# Altium schematic sheet heating_schematic_1.SchDoc (continued)
## Library data of the parts (continued)
C8 = GRM188R72A104KA35D (2): IRON_GATE_C0.1: 100V 100nF X7R ±10% 0603 Multilayer Ceramic Capacitors MLCC - SMD/SMT ROHS; Manufacturer: Murata; Manufacturer Lifecycle Status: IN PRODUCTION; Case Code (Imperial): 0603; Case Code (Metric): 1608; Case/Package: 0603; Category: Ceramic Capacitors; Component Kind: Standard; ComponentLink1Description: https://datasheet.octopart.com:443/GRM188R72A104KA35D-Murata-datasheet-44108070.pdf?src-supplier=Upverter; ComponentLink1URL: https://datasheet.octopart.com:443/GRM188R72A104KA35D-Murata-datasheet-44108070.pdf?src-supplier=Upverter; ComponentLink2Description: https://datasheet.octopart.com:443/GRM188R72A104KA35D-Murata-datasheet-141275792.pdf?src-supplier=Future+Electronics; ComponentLink2URL: https://datasheet.octopart.com:443/GRM188R72A104KA35D-Murata-datasheet-141275792.pdf?src-supplier=Future+Electronics; ComponentLink3Description: https://datasheet.octopart.com:443/GRM188R72A104KA35D-Murata-datasheet-32479577.pdf?src-supplier=Future+Electronics; ComponentLink3URL: https://datasheet.octopart.com:443/GRM188R72A104KA35D-Murata-datasheet-32479577.pdf?src-supplier=Future+Electronics; ComponentLink4Description: https://datasheet.octopart.com:443/GRM188R72A104KA35D-Murata-datasheet-14397338.pdf?src-supplier=Future+Electronics; ComponentLink4URL: https://datasheet.octopart.com:443/GRM188R72A104KA35D-Murata-datasheet-14397338.pdf?src-supplier=Future+Electronics; ComponentLink5Description: https://datasheet.octopart.com:443/GRM188R72A104KA35D-Murata-datasheet-62317223.pdf?src-supplier=element14+APAC; ComponentLink5URL: https://datasheet.octopart.com:443/GRM188R72A104KA35D-Murata-datasheet-62317223.pdf?src-supplier=element14+APAC; ComponentLink6Description: https://datasheet.octopart.com:443/GRM188R72A104KA35D-Murata-datasheet-17853129.pdf?src-supplier=element14+APAC; ComponentLink6URL: https://datasheet.octopart.com:443/GRM188R72A104KA35D-Murata-datasheet-17853129.pdf?src-supplier=element14+APAC; ComponentLink7Description: https://datasheet.octopart.com:443/GRM188R72A104KA35D-Murata-datasheet-8817987.pdf?src-supplier=Newark; ComponentLink7URL: https://datasheet.octopart.com:443/GRM188R72A104KA35D-Murata-datasheet-8817987.pdf?src-supplier=Newark; ComponentLink8Description: https://datasheet.octopart.com:443/GRM188R72A104KA35D-Murata-datasheet-5396244.pdf?src-supplier=_legacy+Avnet; ComponentLink8URL: https://datasheet.octopart.com:443/GRM188R72A104KA35D-Murata-datasheet-5396244.pdf?src-supplier=_legacy+Avnet; ComponentLink9Description: https://datasheet.octopart.com/GRM188R72A104KA35D-Murata-datasheet-12546599.pdf; ComponentLink9URL: https://datasheet.octopart.com/GRM188R72A104KA35D-Murata-datasheet-12546599.pdf; ComponentLink10Description: https://datasheet.octopart.com/GRM188R72A104KA35D-Murata-datasheet-12533551.pdf; ComponentLink10URL: https://datasheet.octopart.com/GRM188R72A104KA35D-Murata-datasheet-12533551.pdf; ComponentLink11Description: https://datasheet.octopart.com/GRM188R72A104KA35D-Murata-datasheet-12515295.pdf; ComponentLink11URL: https://datasheet.octopart.com/GRM188R72A104KA35D-Murata-datasheet-12515295.pdf; ComponentLink12Description: https://datasheet.octopart.com/GRM188R72A104KA35D-Murata-datasheet-12510076.pdf; ComponentLink12URL: https://datasheet.octopart.com/GRM188R72A104KA35D-Murata-datasheet-12510076.pdf; ComponentLink13Description: https://datasheet.octopart.com/GRM188R72A104KA35D-Murata-datasheet-11978611.pdf; ComponentLink13URL: https://datasheet.octopart.com/GRM188R72A104KA35D-Murata-datasheet-11978611.pdf; ComponentLink14Description: https://datasheet.octopart.com/GRM188R72A104KA35D-Murata-datasheet-10025737.pdf; ComponentLink14URL: https://datasheet.octopart.com/GRM188R72A104KA35D-Murata-datasheet-10025737.pdf; ComponentLink15Description: https://datasheet.octopart.com/GRM188R72A104KA35D-Murata-datasheet-8819587.pdf; ComponentLink15URL: https://datasheet.octopart.com/GRM188R72A104KA35D-Murata-datasheet-8819587.pdf; ComponentLink16Description: https://datasheet.octopart.com:443/GRM188R72A104KA35D-Murata-datasheet-165579666.pdf?src-supplier=TME; ComponentLink16URL: https://datasheet.octopart.com:443/GRM188R72A104KA35D-Murata-datasheet-165579666.pdf?src-supplier=TME; ComponentLink17Description: https://datasheet.octopart.com:443/GRM188R72A104KA35D-Murata-datasheet-59876780.pdf?src-supplier=TME; ComponentLink17URL: https://datasheet.octopart.com:443/GRM188R72A104KA35D-Murata-datasheet-59876780.pdf?src-supplier=TME; ComponentLink18Description: https://datasheet.octopart.com:443/GRM188R72A104KA35D-Murata-datasheet-140705814.pdf?src-supplier=Ciiva; ComponentLink18URL: https://datasheet.octopart.com:443/GRM188R72A104KA35D-Murata-datasheet-140705814.pdf?src-supplier=Ciiva; ComponentLink19Description: https://datasheet.octopart.com:443/GRM188R72A104KA35D-Murata-datasheet-138743342.pdf?src-supplier=Ciiva; ComponentLink19URL: https://datasheet.octopart.com:443/GRM188R72A104KA35D-Murata-datasheet-138743342.pdf?src-supplier=Ciiva; ComponentLink20Description: https://datasheet.octopart.com:443/GRM188R72A104KA35D-Murata-datasheet-120101189.pdf?src-supplier=Megastar; ComponentLink20URL: https://datasheet.octopart.com:443/GRM188R72A104KA35D-Murata-datasheet-120101189.pdf?src-supplier=Megastar; ComponentLink21Description: https://datasheet.octopart.com:443/GRM188R72A104KA35D-Murata-datasheet-7591359.pdf?src-supplier=Jameco; ComponentLink21URL: https://datasheet.octopart.com:443/GRM188R72A104KA35D-Murata-datasheet-7591359.pdf?src-supplier=Jameco; ComponentLink22Description: https://datasheet.octopart.com:443/GRM188R72A104KA35D-Murata-datasheet-118811.pdf?src-supplier=DigiKey; ComponentLink22URL: https://datasheet.octopart.com:443/GRM188R72A104KA35D-Murata-datasheet-118811.pdf?src-supplier=DigiKey; ComponentLink23Description: http://sigma.octopart.com:80/reach_statement/Murata-company-16.pdf?src-supplier=Compliance+Statements; ComponentLink23URL: http://sigma.octopart.com:80/reach_statement/Murata-company-16.pdf?src-supplier=Compliance+Statements; Country of Origin: Philippines; Depth: 800µm; Dielectric: X7R; Dielectric Material: Ceramic; Export Control Classification Number (ECCN) Code: EAR99; Harmonized Tariff Schedule (HTS) Code: 8532.24.00.20; Height: 800µm; Introduction Date: 2006-12-28; Lead Free: Lead Free; Length: 1.6mm; Library Name: Simulation Generic Components.IntLib; Lifecycle Status: Production; Manufacturer Part Number: GRM188R72A104KA35D; Material: Ceramic; Max Operating Temperature: 125°C; Min Operating Temperature: -55°C; Mounting Technology: Surface Mount; Number of Terminals: 2; Packaging: Tape and Reel; Pins: 2; Pricing: 1=0.14, 10=0.079, 50=0.0556, 100=0.0483, 500=0.03618, 1000=0.03244 (USD); Radiation Hardening: No; REACH SVHC: Yes; RoHS: Compliant; Schedule B: 8532240020, 8532240020|8532240020, 8532240020|8532240020|8532240020, 8532240020|8532240020|8532240020|8532240020; Stock: 1621610; Supplier: DigiKey; Supplier Part Number: 490-3285-1-ND; Termination: SMD/SMT; Thickness: 800µm; Tolerance: 10%; Voltage: 100V; Voltage Rating: 100V; Voltage Rating (DC): 100V; Weight: 6.293594mg; Width: 800µm
C9 = CL10B105KA8NNNC: IRON_GATE_C1: 25V 1uF X7R ±10% 0603 Multilayer Ceramic Capacitors MLCC - SMD/SMT ROHS; Manufacturer: Samsung Electro-Mechanics; Case Code (Imperial): 0603; Case Code (Metric): 1608; Case/Package: 0603; Category: Ceramic Capacitors; China RoHS: Compliant; Component Kind: Standard; ComponentLink1Description: https://datasheet.octopart.com:443/CL10B105KA8NNNC-Samsung-Electro-Mechanics-datasheet-182019882.pdf?src-supplier=IHS; ComponentLink1URL: https://datasheet.octopart.com:443/CL10B105KA8NNNC-Samsung-Electro-Mechanics-datasheet-182019882.pdf?src-supplier=IHS; ComponentLink2Description: https://datasheet.octopart.com:443/CL10B105KA8NNNC-Samsung-Electro-Mechanics-datasheet-106547815.pdf?src-supplier=Upverter; ComponentLink2URL: https://datasheet.octopart.com:443/CL10B105KA8NNNC-Samsung-Electro-Mechanics-datasheet-106547815.pdf?src-supplier=Upverter; ComponentLink3Description: https://datasheet.octopart.com:443/CL10B105KA8NNNC-Samsung-Electro-Mechanics-datasheet-137699931.pdf?src-supplier=Samsung+SCT; ComponentLink3URL: https://datasheet.octopart.com:443/CL10B105KA8NNNC-Samsung-Electro-Mechanics-datasheet-137699931.pdf?src-supplier=Samsung+SCT; ComponentLink4Description: https://datasheet.octopart.com:443/CL10B105KA8NNNC-Samsung-Electro-Mechanics-datasheet-116890983.pdf?src-supplier=Future+Electronics; ComponentLink4URL: https://datasheet.octopart.com:443/CL10B105KA8NNNC-Samsung-Electro-Mechanics-datasheet-116890983.pdf?src-supplier=Future+Electronics; ComponentLink5Description: https://datasheet.octopart.com:443/CL10B105KA8NNNC-Samsung-datasheet-12527851.pdf?src-supplier=Future+Electronics; ComponentLink5URL: https://datasheet.octopart.com:443/CL10B105KA8NNNC-Samsung-datasheet-12527851.pdf?src-supplier=Future+Electronics; ComponentLink6Description: https://datasheet.octopart.com:443/CL10B105KA8NNNC-Samsung-datasheet-26669022.pdf?src-supplier=Future+Electronics; ComponentLink6URL: https://datasheet.octopart.com:443/CL10B105KA8NNNC-Samsung-datasheet-26669022.pdf?src-supplier=Future+Electronics; ComponentLink7Description: https://datasheet.octopart.com:443/CL10B105KA8NNNC-Samsung-Electro-Mechanics-datasheet-149136795.pdf?src-supplier=Future+Electronics; ComponentLink7URL: https://datasheet.octopart.com:443/CL10B105KA8NNNC-Samsung-Electro-Mechanics-datasheet-149136795.pdf?src-supplier=Future+Electronics; ComponentLink8Description: https://datasheet.octopart.com:443/CL10B105KA8NNNC-Samsung-datasheet-37081328.pdf?src-supplier=Future+Electronics; ComponentLink8URL: https://datasheet.octopart.com:443/CL10B105KA8NNNC-Samsung-datasheet-37081328.pdf?src-supplier=Future+Electronics; ComponentLink9Description: https://datasheet.octopart.com/CL10B105KA8NNNC-Samsung-Electro-Mechanics-datasheet-97040617.pdf; ComponentLink9URL: https://datasheet.octopart.com/CL10B105KA8NNNC-Samsung-Electro-Mechanics-datasheet-97040617.pdf; ComponentLink10Description: https://datasheet.octopart.com/CL10B105KA8NNNC-Samsung-Electro-Mechanics-datasheet-8324245.pdf; ComponentLink10URL: https://datasheet.octopart.com/CL10B105KA8NNNC-Samsung-Electro-Mechanics-datasheet-8324245.pdf; ComponentLink11Description: https://datasheet.octopart.com:443/CL10B105KA8NNNC-Samsung-datasheet-59220082.pdf?src-supplier=TME; ComponentLink11URL: https://datasheet.octopart.com:443/CL10B105KA8NNNC-Samsung-datasheet-59220082.pdf?src-supplier=TME; ComponentLink12Description: https://datasheet.octopart.com:443/CL10B105KA8NNNC-Samsung-Electro-Mechanics-datasheet-11897796.pdf?src-supplier=Arrow+Electronics; ComponentLink12URL: https://datasheet.octopart.com:443/CL10B105KA8NNNC-Samsung-Electro-Mechanics-datasheet-11897796.pdf?src-supplier=Arrow+Electronics; Contact Plating: Tin; Country of Origin: Mainland China, Philippines, South Korea; Depth: 800µm; Dielectric: X7R; Dielectric Material: Ceramic; Export Control Classification Number (ECCN) Code: EAR99; Harmonized Tariff Schedule (HTS) Code: 8532.24.00.20; Height: 900µm; Introduction Date: 2009-11-02; Length: 1.6mm; Library Name: Simulation Generic Components.IntLib; Lifecycle Status: Production; Manufacturer Part Number: CL10B105KA8NNNC; Material: Ceramic; Max Operating Temperature: 125°C; Min Operating Temperature: -55°C; Mounting Technology: Surface Mount; Number of Terminals: 2; Pricing: 1=0.1, 10=0.036, 50=0.0244, 100=0.0208, 500=0.01486, 1000=0.01305 (USD); Radiation Hardening: No; REACH SVHC: Yes; RoHS: Compliant; Schedule B: 8532240020, 8532240020|8532240020, 8532240020|8532240020|8532240020, 8532240020|8532240020|8532240020|8532240020; Stock: 996256; Supplier: DigiKey; Supplier Part Number: 1276-1184-1-ND; Thickness: 889µm; Tolerance: 10%; Voltage: 25V; Voltage Rating: 25V; Voltage Rating (DC): 25V; Width: 800µm
D1 = SMBJ33A: TVS_DIODE: SMBJ Series 53.3 V 0.6 kW Surface Mount Uni-Directional TVS Diode - DO-214AA; Manufacturer: Littelfuse; Breakdown Voltage: 38.65V; Clamping Voltage: 53.3V; Direction: Unidirectional; Element Configuration: Single; Forward Voltage: 3.5V; Leakage Current: 1µA; Max Breakdown Voltage: 40.6V; Max Junction Temperature (Tj): 150°C; Max Power Dissipation: 5W; Max Surge Current: 11.3A; Min Breakdown Voltage: 36.7V; Number of Channels: 1; Operating Supply Voltage: 33V; Peak Pulse Power: 600W; Power Line Protection: No; Test Current: 1mA; Case/Package: SMB; Category: TVS Diodes; China RoHS: Non-Compliant; Component Kind: Standard; ComponentLink1Description: https://datasheet.octopart.com:443/SMBJ33A-Littelfuse-datasheet-180609570.pdf?src-supplier=IHS; ComponentLink1URL: https://datasheet.octopart.com:443/SMBJ33A-Littelfuse-datasheet-180609570.pdf?src-supplier=IHS; ComponentLink2Description: https://datasheet.octopart.com:443/SMBJ33A-Littelfuse-datasheet-98958142.pdf?src-supplier=Littelfuse+SCT; ComponentLink2URL: https://datasheet.octopart.com:443/SMBJ33A-Littelfuse-datasheet-98958142.pdf?src-supplier=Littelfuse+SCT; ComponentLink3Description: https://datasheet.octopart.com:443/SMBJ33A-Littelfuse-datasheet-10854588.pdf?src-supplier=element14+APAC; ComponentLink3URL: https://datasheet.octopart.com:443/SMBJ33A-Littelfuse-datasheet-10854588.pdf?src-supplier=element14+APAC; ComponentLink4Description: https://datasheet.octopart.com:443/SMBJ33A-Littelfuse-datasheet-5319440.pdf?src-supplier=element14+APAC; ComponentLink4URL: https://datasheet.octopart.com:443/SMBJ33A-Littelfuse-datasheet-5319440.pdf?src-supplier=element14+APAC; ComponentLink5Description: https://datasheet.octopart.com:443/SMBJ33A-Littelfuse-datasheet-145017494.pdf?src-supplier=Farnell; ComponentLink5URL: https://datasheet.octopart.com:443/SMBJ33A-Littelfuse-datasheet-145017494.pdf?src-supplier=Farnell; ComponentLink6Description: https://datasheet.octopart.com:443/SMBJ33A-Littelfuse-datasheet-63710821.pdf?src-supplier=Farnell; ComponentLink6URL: https://datasheet.octopart.com:443/SMBJ33A-Littelfuse-datasheet-63710821.pdf?src-supplier=Farnell; ComponentLink7Description: https://datasheet.octopart.com:443/SMBJ33A-Littelfuse-datasheet-170904961.pdf?src-supplier=Future+Electronics; ComponentLink7URL: https://datasheet.octopart.com:443/SMBJ33A-Littelfuse-datasheet-170904961.pdf?src-supplier=Future+Electronics; ComponentLink8Description: https://datasheet.octopart.com:443/SMBJ33A-Littelfuse-datasheet-27889791.pdf?src-supplier=Fuses+Unlimited; ComponentLink8URL: https://datasheet.octopart.com:443/SMBJ33A-Littelfuse-datasheet-27889791.pdf?src-supplier=Fuses+Unlimited; ComponentLink9Description: https://datasheet.octopart.com:443/SMBJ33A-Littelfuse-datasheet-871.pdf?src-supplier=DigiKey; ComponentLink9URL: https://datasheet.octopart.com:443/SMBJ33A-Littelfuse-datasheet-871.pdf?src-supplier=DigiKey; Composition: Zener; Country of Origin: Mainland China, Philippines; Export Control Classification Number (ECCN) Code: EAR99; Harmonized Tariff Schedule (HTS) Code: 8541.10.00.50; Height: 2.61mm; Introduction Date: 1996-01-01; Lead Free: Lead Free; Length: 4.57mm; Lifecycle Status: Production; Manufacturer Part Number: SMBJ33A; Max Operating Temperature: 150°C; Max Reverse Leakage Current: 1µA; Min Operating Temperature: -65°C; Mounting Technology: Surface Mount; Number of Elements: 1; Number of Terminals: 2; Number of Unidirectional Channels: 1; Packaging: Tape & Reel; Peak Pulse Current: 11.3A; Peak Reverse Current: 1µA; Pins: 2; Polarity: Unidirectional; Power Rating: 600W; Pricing: 1=0.3, 10=0.214, 100=0.105, 500=0.102, 1000=0.098 (USD); Radiation Hardening: No; REACH SVHC: No; Reverse Breakdown Voltage: 36.7V; Reverse Standoff Voltage: 33V; Reverse Voltage: 33V; RoHS: Compliant; Schedule B: 8541100080, 8541100080|8541100080|8541100080|8541100080|8541100080|8541100080|8541100080|8541100080|8541100080|8541100080|8541100080|8541100080|8541100080|8541100080|8541100080|8541100080|8541100080|8541100080|8541100080|8541100080|8541100080|8541100080|8541100080|85; Stock: 63760; Supplier: DigiKey; Supplier Part Number: SMBJ33ALFCT-ND; Termination: SMD/SMT; Voltage Rating (DC): 33V; Width: 3.94mm; Library Name: Americas - University of Waterloo - Waterloo Space Soldering Team (WSST)
F1 = 0680L9100-05: INPUT_FUSE: Electric Fuse, Time Lag Blow, 10A, 75VAC, 50VDC, 100A (IR), Surface Mount, 2410; Manufacturer: Bel Fuse; Time to Trip: 10ms; Voltage Rating (AC): 75V; Voltage Rating (DC): 50V; Category: Fuses; China RoHS: Non-Compliant; Component Kind: Standard; ComponentLink1Description: https://datasheet.octopart.com:443/0680L9100-05-Bel-Fuse-datasheet-150518765.pdf?src-supplier=Master+Electronics; ComponentLink1URL: https://datasheet.octopart.com:443/0680L9100-05-Bel-Fuse-datasheet-150518765.pdf?src-supplier=Master+Electronics; ComponentLink2Description: https://datasheet.octopart.com:443/0680L9100-05-Bel-Fuse-datasheet-139885425.pdf?src-supplier=Sager+Electronics; ComponentLink2URL: https://datasheet.octopart.com:443/0680L9100-05-Bel-Fuse-datasheet-139885425.pdf?src-supplier=Sager+Electronics; ComponentLink3Description: https://datasheet.octopart.com:443/0680L9100-05-Bel-Fuse-datasheet-137316783.pdf?src-supplier=Sager+Electronics; ComponentLink3URL: https://datasheet.octopart.com:443/0680L9100-05-Bel-Fuse-datasheet-137316783.pdf?src-supplier=Sager+Electronics; Country of Origin: Mainland China; Current Rating: 10A; Export Control Classification Number (ECCN) Code: EAR99; Harmonized Tariff Schedule (HTS) Code: 8536.10.00.40; Height: 2.54mm; Introduction Date: 2019-07-26; Length: 6.1mm; Lifecycle Status: Production; Manufacturer Part Number: 0680L9100-05; Max Operating Temperature: 125°C; Min Operating Temperature: -55°C; Mounting Technology: Surface Mount; Pricing: 1=0.74, 5=0.61, 10=0.563, 50=0.467, 100=0.4309, 250=0.38732, 500=0.35722, 1000=0.32936 (USD); REACH SVHC: No; RoHS: Compliant; Stock: 10120; Supplier: DigiKey; Supplier Part Number: 5923-0680L9100-05CT-ND; Library Name: Americas - University of Waterloo - Waterloo Space Soldering Team (WSST)
J1 = OSTTC022162: Terminal Block Header 2-Position Top Screw 0.200" (5.08mm) PC-Pins; Manufacturer: On Shore Technology; Approvals: UL, cUL; Current: 15A; Number of Contacts: 2; Number of Levels: 1; Plating: Steel; Voltage: 300V; Wire Gauge (Max): 22AWG; Wire Gauge (Min): 14AWG; Category: Terminal Blocks; Color: Blue; Component Kind: Standard; ComponentLink1Description: https://datasheet.octopart.com:443/OSTTC022162-On-Shore-Technology-datasheet-154400322.pdf?src-supplier=IHS; ComponentLink1URL: https://datasheet.octopart.com:443/OSTTC022162-On-Shore-Technology-datasheet-154400322.pdf?src-supplier=IHS; ComponentLink2Description: https://datasheet.octopart.com:443/OSTTC022162-On-Shore-Technology-datasheet-138504.pdf?src-supplier=Jameco; ComponentLink2URL: https://datasheet.octopart.com:443/OSTTC022162-On-Shore-Technology-datasheet-138504.pdf?src-supplier=Jameco; Contact Material: Brass; Contact Plating: Tin; Country of Origin: Mainland China, Tunisia; Current Rating: 15A; Export Control Classification Number (ECCN) Code: EAR99; Flammability Rating: UL94 V-0; Harmonized Tariff Schedule (HTS) Code: 8536.90.40.00; Height: 10mm; Housing Color: Blue; Housing Material: Thermoplastic; Introduction Date: 2007-04-24; Length: 10.2mm; Lifecycle Status: Production; Manufacturer Part Number: OSTTC022162; Max Current Rating: 15A; Max Operating Temperature: 105°C; Min Operating Temperature: -30°C; Mounting Technology: Screw; Number of Positions: 2; Number of Positions Per Level: 2; Number of Rows: 1; Packaging: Bulk; Pitch: 5.08mm; Pricing: 1=0.57, 10=0.423, 25=0.3692, 50=0.3322, 100=0.3, 250=0.26432, 500=0.24256, 1000=0.22482, 5000=0.19622 (USD); REACH SVHC: Yes; RoHS: Compliant; Stock: 7662; Supplier: DigiKey; Supplier Part Number: ED2609-ND; Termination: Screw; Voltage Rating: 300V; Width: 7.6mm; Wire/Cable Gauge: 14AWG; Library Name: heating_sch_lib1.SchLib
J2 = OSTYK51102030: Conn Terminal Block 2 POS 9.5mm Solder ST Thru-Hole 30A; Manufacturer: On Shore Technology; Approvals: UL, cUL; Number of Circuits: 2; Number of Levels: 1; Pitch: 9.4996mm; Voltage Rating: 300V; Wire Gauge (Max): 22AWG; Wire Gauge (Min): 10AWG; Category: Terminal Blocks; Color: Black; Component Kind: Standard; ComponentLink1Description: https://datasheet.octopart.com:443/OSTYK51102030-On-Shore-Technology-datasheet-180957037.pdf?src-supplier=IHS; ComponentLink1URL: https://datasheet.octopart.com:443/OSTYK51102030-On-Shore-Technology-datasheet-180957037.pdf?src-supplier=IHS; Country of Origin: Mainland China, Tunisia; Current Rating: 30A; Export Control Classification Number (ECCN) Code: EAR99; Flammability Rating: UL94 V-0; Harmonized Tariff Schedule (HTS) Code: 8536.90.40.00; Insulation Material: Nylon; Introduction Date: 2015-07-28; Lifecycle Status: Production; Manufacturer Part Number: OSTYK51102030; Max Operating Temperature: 115°C; Min Operating Temperature: -40°C; Mounting Technology: Screw; Number of Contacts: 2; Number of Rows: 1; Number of Wire Entries: 2; Packaging: Bulk; Pricing: 1=1.22, 10=0.886, 25=0.7716, 50=0.6962, 100=0.6316, 250=0.56228, 500=0.52082, 1000=0.48752, 5000=0.43471 (USD); REACH SVHC: Yes; RoHS: Compliant; Stock: 4503; Supplier: DigiKey; Supplier Part Number: ED2953-ND; Wire/Cable Gauge: 10AWG; Library Name: heating_sch_lib1.SchLib
J3 J4 = OSTTE020104: Conn Terminal Block 2 POS 3.5mm Solder ST Thru-Hole 10A; Manufacturer: On Shore Technology; Approvals: UL, cUL; Number of Contacts: 2; Number of Levels: 1; Pitch: 3.5052mm; Plating: Steel; Voltage: 125V; Wire Gauge (Max): 26AWG; Wire Gauge (Min): 16AWG; Category: Terminal Blocks; Color: Black; Component Kind: Standard; ComponentLink1Description: https://datasheet.octopart.com:443/OSTTE020104-On-Shore-Technology-datasheet-159568345.pdf?src-supplier=IHS; ComponentLink1URL: https://datasheet.octopart.com:443/OSTTE020104-On-Shore-Technology-datasheet-159568345.pdf?src-supplier=IHS; ComponentLink2Description: https://datasheet.octopart.com:443/OSTTE020104-On-Shore-Technology-datasheet-17019721.pdf?src-supplier=Upverter; ComponentLink2URL: https://datasheet.octopart.com:443/OSTTE020104-On-Shore-Technology-datasheet-17019721.pdf?src-supplier=Upverter; Contact Material: Tin; Contact Plating: Tin; Country of Origin: Mainland China, Tunisia; Current: 10A; Current Rating: 10A; Export Control Classification Number (ECCN) Code: EAR99; Harmonized Tariff Schedule (HTS) Code: 8536.90.40.00; Height: 8.7mm; Housing Material: Thermoplastic; Introduction Date: 2013-10-15; Lifecycle Status: Production; Manufacturer Part Number: OSTTE020104; Max Operating Temperature: 105°C; Min Operating Temperature: -40°C; Mounting Technology: Screw; Number of Positions Per Level: 2; Number of Rows: 1; Packaging: Bulk; Pins: 2; Pricing: 1=0.48, 10=0.361, 25=0.3152, 50=0.2836, 100=0.2558, 250=0.225, 500=0.2061, 1000=0.19063, 5000=0.16559 (USD); REACH SVHC: Yes; RoHS: Compliant; Stock: 15539; Supplier: DigiKey; Supplier Part Number: ED2740-ND; Termination: Screw; Voltage Rating: 125V; Wire/Cable Gauge: 16AWG; Library Name: heating_sch_lib1.SchLib
Q1 Q2 = IRLZ44NSTRLPBF: IRON_MOSFET: Mosfet, Power; N-ch; Vdss 55V; Rds(on) 0.022 Ohm; Id 47A; D2PAK; Pd 110W; Vgs +/-16V; -55; Manufacturer: Infineon; Drain to Source Resistance: 25mΩ; Element Configuration: Single; Fall Time: 15ns; Gate to Source Voltage (Vgs): 16V; Input Capacitance: 1.7nF; Max Junction Temperature (Tj): 175°C; Max Power Dissipation: 3.8W; Number of Channels: 1; On-State Resistance: 22mΩ; Rise Time: 84ns; Threshold Voltage: 2V; Turn-Off Delay Time: 26ns; Turn-On Delay Time: 11ns; Value: 22mΩ; Case/Package: D2PAK; Category: MOSFETs; China RoHS: Non-Compliant; Component Kind: Standard; ComponentLink1Description: https://datasheet.octopart.com:443/IRLZ44NSTRLPBF-Infineon-datasheet-7566683.pdf?src-supplier=Newark; ComponentLink1URL: https://datasheet.octopart.com:443/IRLZ44NSTRLPBF-Infineon-datasheet-7566683.pdf?src-supplier=Newark; ComponentLink2Description: https://datasheet.octopart.com:443/IRLZ44NSTRLPBF-International-Rectifier-datasheet-9326.pdf?src-supplier=Newark; ComponentLink2URL: https://datasheet.octopart.com:443/IRLZ44NSTRLPBF-International-Rectifier-datasheet-9326.pdf?src-supplier=Newark; ComponentLink3Description: https://datasheet.octopart.com:443/IRLZ44NSTRLPBF-Infineon-datasheet-180073547.pdf?src-supplier=IHS; ComponentLink3URL: https://datasheet.octopart.com:443/IRLZ44NSTRLPBF-Infineon-datasheet-180073547.pdf?src-supplier=IHS; ComponentLink4Description: https://datasheet.octopart.com:443/IRLZ44NSTRLPBF-Infineon-datasheet-8534184.pdf?src-supplier=Farnell; ComponentLink4URL: https://datasheet.octopart.com:443/IRLZ44NSTRLPBF-Infineon-datasheet-8534184.pdf?src-supplier=Farnell; ComponentLink5Description: https://datasheet.octopart.com:443/IRLZ44NSTRLPBF-Infineon-datasheet-9669855.pdf?src-supplier=RS+(Formerly+Allied+Electronics); ComponentLink5URL: https://datasheet.octopart.com:443/IRLZ44NSTRLPBF-Infineon-datasheet-9669855.pdf?src-supplier=RS+(Formerly+Allied+Electronics); ComponentLink6Description: https://datasheet.octopart.com:443/IRLZ44NSTRLPBF-International-Rectifier-datasheet-8827662.pdf?src-supplier=Jameco; ComponentLink6URL: https://datasheet.octopart.com:443/IRLZ44NSTRLPBF-International-Rectifier-datasheet-8827662.pdf?src-supplier=Jameco; ComponentLink7Description: https://datasheet.octopart.com:443/IRLZ44NSTRLPBF-International-Rectifier-datasheet-49149.pdf?src-supplier=DigiKey; ComponentLink7URL: https://datasheet.octopart.com:443/IRLZ44NSTRLPBF-International-Rectifier-datasheet-49149.pdf?src-supplier=DigiKey; ComponentLink8Description: http://sigma.octopart.com:80/conflict_mineral_statement/Infineon-company-51.pdf?src-supplier=Compliance+Statements; ComponentLink8URL: http://sigma.octopart.com:80/conflict_mineral_statement/Infineon-company-51.pdf?src-supplier=Compliance+Statements; ComponentLink9Description: http://sigma.octopart.com:80/reach_statement/International-Rectifier-company-55.pdf?src-supplier=Compliance+Statements; ComponentLink9URL: http://sigma.octopart.com:80/reach_statement/International-Rectifier-company-55.pdf?src-supplier=Compliance+Statements; ComponentLink10Description: http://sigma.octopart.com:80/rohs_statement/International-Rectifier-company-54.pdf?src-supplier=Compliance+Statements; ComponentLink10URL: http://sigma.octopart.com:80/rohs_statement/International-Rectifier-company-54.pdf?src-supplier=Compliance+Statements; Continuous Drain Current (ID): 47A; Country of Origin: Mainland China; Current Rating: 47A; Drain to Source Breakdown Voltage: 55V; Drain to Source Voltage (Vdss): 55V; Export Control Classification Number (ECCN) Code: EAR99; Height: 5.084mm; Introduction Date: 1996-11-01; Lead Free: Lead Free; Length: 10.668mm; Lifecycle Status: NRND; Manufacturer Part Number: IRLZ44NSTRLPBF; Max Operating Temperature: 175°C; Min Breakdown Voltage: 55V; Min Operating Temperature: -55°C; Mounting Technology: Surface Mount; Number of Elements: 1; Number of Terminals: 2; Package Quantity: 800; Pins: 3; Power Dissipation: 3.8W; Pricing: 1=2.31, 10=1.478, 100=1.0007 (USD); Radiation Hardening: No; Rds On Max: 22mΩ; REACH SVHC: No; RoHS: Compliant; Schedule B: 8541290080, 8541290080|8541290080|8541290080|8541290080|8541290080; Stock: 4723; Supplier: DigiKey; Supplier Part Number: IRLZ44NSTRLPBFCT-ND; Voltage Rating (DC): 55V; Width: 9.65mm; Library Name: Americas - University of Waterloo - Waterloo Space Soldering Team (WSST)
R1 R3 = CRCW060350R0FKTA: MOSFET_GATE_SIGNAL: Res Thick Film 0603 50 Ohm 1% 0.1W(1/10W) ±100ppm/C Pad SMD Automotive T/R; Manufacturer: Vishay; Power Dissipation: 100mW; Temperature Coefficient: 100ppm/°C; Voltage Rating: 75V; Case Code (Imperial): 0603; Case Code (Metric): 1608; Case/Package: 0603; Category: Chip SMD Resistors; Component Kind: Standard; ComponentLink1Description: https://datasheet.octopart.com:443/CRCW060350R0FKTA-Vishay-datasheet-182027428.pdf?src-supplier=IHS; ComponentLink1URL: https://datasheet.octopart.com:443/CRCW060350R0FKTA-Vishay-datasheet-182027428.pdf?src-supplier=IHS; ComponentLink2Description: https://datasheet.octopart.com/D-CRCW-Lead-%28Pb%29-Bearing-Thick-Film%2C-Rectangular-Chip-Resistors-Vishay-datasheet-family-72.pdf; ComponentLink2URL: https://datasheet.octopart.com/D-CRCW-Lead-%28Pb%29-Bearing-Thick-Film%2C-Rectangular-Chip-Resistors-Vishay-datasheet-family-72.pdf; ComponentLink3Description: https://datasheet.octopart.com/CRCW060350R0FKTA-Vishay-Dale-datasheet-13242068.pdf; ComponentLink3URL: https://datasheet.octopart.com/CRCW060350R0FKTA-Vishay-Dale-datasheet-13242068.pdf; ComponentLink4Description: https://datasheet.octopart.com/CRCW060350R0FKTA-Vishay-Dale-datasheet-13195083.pdf; ComponentLink4URL: https://datasheet.octopart.com/CRCW060350R0FKTA-Vishay-Dale-datasheet-13195083.pdf; ComponentLink5Description: https://datasheet.octopart.com/CRCW060350R0FKTA-Vishay-Dale-datasheet-13034211.pdf; ComponentLink5URL: https://datasheet.octopart.com/CRCW060350R0FKTA-Vishay-Dale-datasheet-13034211.pdf; ComponentLink6Description: https://datasheet.octopart.com/CRCW060350R0FKTA-Vishay-Dale-datasheet-12600034.pdf; ComponentLink6URL: https://datasheet.octopart.com/CRCW060350R0FKTA-Vishay-Dale-datasheet-12600034.pdf; ComponentLink7Description: https://datasheet.octopart.com/CRCW060350R0FKTA-Vishay-Dale-datasheet-12532161.pdf; ComponentLink7URL: https://datasheet.octopart.com/CRCW060350R0FKTA-Vishay-Dale-datasheet-12532161.pdf; ComponentLink8Description: https://datasheet.octopart.com/CRCW060350R0FKTA-Vishay-Dale-datasheet-12517896.pdf; ComponentLink8URL: https://datasheet.octopart.com/CRCW060350R0FKTA-Vishay-Dale-datasheet-12517896.pdf; ComponentLink9Description: https://datasheet.octopart.com/CRCW060350R0FKTA-Vishay-Dale-datasheet-12509762.pdf; ComponentLink9URL: https://datasheet.octopart.com/CRCW060350R0FKTA-Vishay-Dale-datasheet-12509762.pdf; ComponentLink10Description: https://datasheet.octopart.com/CRCW060350R0FKTA-Vishay-Dale-datasheet-10322335.pdf; ComponentLink10URL: https://datasheet.octopart.com/CRCW060350R0FKTA-Vishay-Dale-datasheet-10322335.pdf; ComponentLink11Description: https://datasheet.octopart.com/CRCW060350R0FKTA-Vishay-Dale-datasheet-8788789.pdf; ComponentLink11URL: https://datasheet.octopart.com/CRCW060350R0FKTA-Vishay-Dale-datasheet-8788789.pdf; ComponentLink12Description: https://datasheet.octopart.com/CRCW060350R0FKTA-Vishay-Dale-datasheet-8361388.pdf; ComponentLink12URL: https://datasheet.octopart.com/CRCW060350R0FKTA-Vishay-Dale-datasheet-8361388.pdf; ComponentLink13Description: https://datasheet.octopart.com/CRCW060350R0FKTA-Vishay-Dale-datasheet-5306405.pdf; ComponentLink13URL: https://datasheet.octopart.com/CRCW060350R0FKTA-Vishay-Dale-datasheet-5306405.pdf; ComponentLink14Description: https://datasheet.octopart.com/CRCW060350R0FKTA-Vishay-Dale-datasheet-546287.pdf; ComponentLink14URL: https://datasheet.octopart.com/CRCW060350R0FKTA-Vishay-Dale-datasheet-546287.pdf; ComponentLink15Description: http://sigma.octopart.com:80/rohs_statement/Vishay-company-15.pdf?src-supplier=Compliance+Statements; ComponentLink15URL: http://sigma.octopart.com:80/rohs_statement/Vishay-company-15.pdf?src-supplier=Compliance+Statements; Country of Origin: Israel; Export Control Classification Number (ECCN) Code: EAR99; Harmonized Tariff Schedule (HTS) Code: 8533.21.00.30; Height: 450µm; Introduction Date: 2010-11-24; Lead Free: Contains Lead; Length: 1.55mm; Lifecycle Status: Production; Manufacturer Part Number: CRCW060350R0FKTA; Max Operating Temperature: 155°C; Min Operating Temperature: -55°C; Mounting Technology: Surface Mount; Number of Terminals: 2; Power Rating: 100mW; Pricing: 1=0.16, 10=0.08, 25=0.0624, 50=0.0526, 100=0.044, 250=0.03716, 500=0.03272, 1000=0.02921 (USD); REACH SVHC: No; RoHS: Non-Compliant; Stock: 49542; Supplier: DigiKey; Supplier Part Number: 541-CRCW060350R0FKTACT-ND; Tolerance: 1%; Weight: 2.012816mg; Width: 850µm; Working Voltage: 75V; Library Name: Americas - University of Waterloo - Waterloo Space Soldering Team (WSST)
R2 R4 = RC0603FR-0710KL: MOSFET_GATE_SOURCE: 100mW Thick Film Resistors ±100ppm/℃ ±1% 10kΩ 0603 Chip Resistor - Surface Mount ROHS; Manufacturer: Yageo Group; Temperature Coefficient: 100ppm/°C; Voltage Rating: 75V; Voltage Rating (DC): 50V; Case Code (Imperial): 0603; Case Code (Metric): 0603; Case/Package: 0603; Category: Chip SMD Resistors; China RoHS: Non-Compliant; Component Kind: Standard; ComponentLink1Description: https://datasheet.octopart.com:443/RC0603FR-0710KL-Yageo-datasheet-175887523.pdf?src-supplier=IHS; ComponentLink1URL: https://datasheet.octopart.com:443/RC0603FR-0710KL-Yageo-datasheet-175887523.pdf?src-supplier=IHS; ComponentLink2Description: https://datasheet.octopart.com:443/RC0603FR-0710KL-Yageo-datasheet-103092701.pdf?src-supplier=Upverter; ComponentLink2URL: https://datasheet.octopart.com:443/RC0603FR-0710KL-Yageo-datasheet-103092701.pdf?src-supplier=Upverter; ComponentLink3Description: https://datasheet.octopart.com:443/RC0603FR-0710KL-Yageo-datasheet-98239111.pdf?src-supplier=Upverter; ComponentLink3URL: https://datasheet.octopart.com:443/RC0603FR-0710KL-Yageo-datasheet-98239111.pdf?src-supplier=Upverter; ComponentLink4Description: https://datasheet.octopart.com:443/RC0603FR-0710KL-Yageo-datasheet-141265859.pdf?src-supplier=Upverter; ComponentLink4URL: https://datasheet.octopart.com:443/RC0603FR-0710KL-Yageo-datasheet-141265859.pdf?src-supplier=Upverter; ComponentLink5Description: https://sigma.octopart.com:443/138820526/technical_drawing/Yageo-RC0603FR-0710KL.pdf?src-supplier=Upverter; ComponentLink5URL: https://sigma.octopart.com:443/138820526/technical_drawing/Yageo-RC0603FR-0710KL.pdf?src-supplier=Upverter; ComponentLink6Description: https://datasheet.octopart.com:443/RC0603FR-0710KL-Yageo-datasheet-8328172.pdf?src-supplier=Farnell; ComponentLink6URL: https://datasheet.octopart.com:443/RC0603FR-0710KL-Yageo-datasheet-8328172.pdf?src-supplier=Farnell; ComponentLink7Description: https://datasheet.octopart.com:443/RC0603FR-0710KL-Yageo-datasheet-13691069.pdf?src-supplier=Farnell; ComponentLink7URL: https://datasheet.octopart.com:443/RC0603FR-0710KL-Yageo-datasheet-13691069.pdf?src-supplier=Farnell; ComponentLink8Description: https://datasheet.octopart.com:443/RC0603FR-0710KL-Yageo-datasheet-62067350.pdf?src-supplier=element14+APAC; ComponentLink8URL: https://datasheet.octopart.com:443/RC0603FR-0710KL-Yageo-datasheet-62067350.pdf?src-supplier=element14+APAC; ComponentLink9Description: https://datasheet.octopart.com:443/RC0603FR-0710KL-Yageo-datasheet-17842380.pdf?src-supplier=element14+APAC; ComponentLink9URL: https://datasheet.octopart.com:443/RC0603FR-0710KL-Yageo-datasheet-17842380.pdf?src-supplier=element14+APAC; ComponentLink10Description: https://datasheet.octopart.com:443/RC0603FR-0710KL-Yageo-datasheet-15599030.pdf?src-supplier=Future+Electronics; ComponentLink10URL: https://datasheet.octopart.com:443/RC0603FR-0710KL-Yageo-datasheet-15599030.pdf?src-supplier=Future+Electronics; ComponentLink11Description: https://datasheet.octopart.com:443/RC0603FR-0710KL-Yageo-Group-datasheet-176638170.pdf?src-supplier=Future+Electronics; ComponentLink11URL: https://datasheet.octopart.com:443/RC0603FR-0710KL-Yageo-Group-datasheet-176638170.pdf?src-supplier=Future+Electronics; ComponentLink12Description: https://datasheet.octopart.com:443/RC0603FR-0710KL-Yageo-datasheet-164759695.pdf?src-supplier=Future+Electronics; ComponentLink12URL: https://datasheet.octopart.com:443/RC0603FR-0710KL-Yageo-datasheet-164759695.pdf?src-supplier=Future+Electronics; ComponentLink13Description: https://datasheet.octopart.com:443/RC0603FR-0710KL-Yageo-datasheet-127823281.pdf?src-supplier=Future+Electronics; ComponentLink13URL: https://datasheet.octopart.com:443/RC0603FR-0710KL-Yageo-datasheet-127823281.pdf?src-supplier=Future+Electronics; ComponentLink14Description: https://datasheet.octopart.com:443/RC0603FR-0710KL-Yageo-datasheet-137700087.pdf?src-supplier=Future+Electronics; ComponentLink14URL: https://datasheet.octopart.com:443/RC0603FR-0710KL-Yageo-datasheet-137700087.pdf?src-supplier=Future+Electronics; ComponentLink15Description: https://datasheet.octopart.com:443/RC0603FR-0710KL-Yageo-datasheet-27584510.pdf?src-supplier=Future+Electronics; ComponentLink15URL: https://datasheet.octopart.com:443/RC0603FR-0710KL-Yageo-datasheet-27584510.pdf?src-supplier=Future+Electronics; ComponentLink16Description: https://datasheet.octopart.com:443/RC0603FR-0710KL-Yageo-datasheet-8324193.pdf?src-supplier=Future+Electronics; ComponentLink16URL: https://datasheet.octopart.com:443/RC0603FR-0710KL-Yageo-datasheet-8324193.pdf?src-supplier=Future+Electronics; ComponentLink17Description: https://datasheet.octopart.com:443/RC0603FR-0710KL-Yageo-datasheet-12596169.pdf?src-supplier=Newark; ComponentLink17URL: https://datasheet.octopart.com:443/RC0603FR-0710KL-Yageo-datasheet-12596169.pdf?src-supplier=Newark; ComponentLink18Description: https://datasheet.octopart.com:443/RC0603FR-0710KL-Yageo-datasheet-503470.pdf?src-supplier=Newark; ComponentLink18URL: https://datasheet.octopart.com:443/RC0603FR-0710KL-Yageo-datasheet-503470.pdf?src-supplier=Newark; ComponentLink19Description: https://datasheet.octopart.com:443/RC0603FR-0710KL-Yageo-datasheet-34211833.pdf?src-supplier=Newark; ComponentLink19URL: https://datasheet.octopart.com:443/RC0603FR-0710KL-Yageo-datasheet-34211833.pdf?src-supplier=Newark; ComponentLink20Description: https://datasheet.octopart.com:443/RC0603FR-0710KL-Yageo-datasheet-79472498.pdf?src-supplier=Megastar; ComponentLink20URL: https://datasheet.octopart.com:443/RC0603FR-0710KL-Yageo-datasheet-79472498.pdf?src-supplier=Megastar; ComponentLink21Description: https://datasheet.octopart.com/RC0603FR-0710KL-Yageo-datasheet-73766026.pdf; ComponentLink21URL: https://datasheet.octopart.com/RC0603FR-0710KL-Yageo-datasheet-73766026.pdf; ComponentLink22Description: https://datasheet.octopart.com:443/RC0603FR-0710KL-Yageo-datasheet-118562.pdf?src-supplier=DigiKey; ComponentLink22URL: https://datasheet.octopart.com:443/RC0603FR-0710KL-Yageo-datasheet-118562.pdf?src-supplier=DigiKey; ComponentLink23Description: http://sigma.octopart.com:80/conflict_mineral_statement/Yageo-company-3.pdf?src-supplier=Compliance+Statements; ComponentLink23URL: http://sigma.octopart.com:80/conflict_mineral_statement/Yageo-company-3.pdf?src-supplier=Compliance+Statements; ComponentLink24Description: http://sigma.octopart.com:80/rohs_statement/Yageo-company-2.pdf?src-supplier=Compliance+Statements; ComponentLink24URL: http://sigma.octopart.com:80/rohs_statement/Yageo-company-2.pdf?src-supplier=Compliance+Statements; Composition: Thick Film; Depth: 800µm; Height: 450µm; Lead Free: Lead Free; Lead Pitch: 300µm; Length: 1.6mm; Lifecycle Status: Production; Manufacturer Part Number: RC0603FR-0710KL; Max Operating Temperature: 155°C; Military Standard: Not; Min Operating Temperature: -55°C; Mounting Technology: Surface Mount; Number of Terminals: 2; Packaging: Tape & Reel; Pins: 2; Power Dissipation: 100mW; Power Rating: 100mW; Pricing: 1=0.1, 10=0.006, 25=0.0056 (USD); REACH SVHC: Yes; RoHS: Compliant; Schedule B: 8533210045, 8533210045|8533210045|8533210045|8533210045|8533210045|8533210045|8533210045|8533210045|8533210045|8533210045|8533210045|8533210045|8533210045|8533210045|8533210045|8533210045|8533210045|8533210045|8533210045|8533210045|8533210045|8533210045|8533210045|85; Stock: 7830707; Supplier: DigiKey; Supplier Part Number: 311-10.0KHRCT-ND; Termination: SMD/SMT; Tolerance: 1%; Weight: 2.012816mg; Width: 800µm; Working Voltage: 75V; Library Name: Americas - University of Waterloo - Waterloo Space Soldering Team (WSST)
U1 = TPSM365R15FRDNR: IRON_BUCK: 3V-to-65V input, 1V-to-16V output, 0.15A synchronous buck converter power module 11-QFN-FCMOD -40 to 125; Manufacturer: Texas Instruments; Manufacturer Lifecycle Status: ACTIVE; Category: Voltage Regulators - Switching; Component Kind: Standard; ComponentLink1Description: https://sigma.octopart.com:443/189719954/technical_drawing/Texas-Instruments-TPSM365R15FRDNR.pdf?src-supplier=Texas+Instruments; ComponentLink1URL: https://sigma.octopart.com:443/189719954/technical_drawing/Texas-Instruments-TPSM365R15FRDNR.pdf?src-supplier=Texas+Instruments; ComponentLink2Description: https://octopart.com:443/opatz8j6/c1?t=3Kn-x-B12yt8cyigldGRK0fr5vnz-lM8Mqch7NdQ2V-LWPUAJNs9So97ApvASyAHA8iK1-lIZdI4MBXwJrEazVMj19I_dzq2jgAHzcK1mcn17bmGuFTeYv25lnKGxLEpMeyj63YQKoaUa7DUxTnjTjc01TQWBA7m8KdFiuahxeRw4IunbreEqAhJd-oxEYd84chu3xmKAs9bqn9Bo89VVi9aAN8KSt32Fzec8xr4Pr_eThut1ziATMBMqOF9rb0h5nPP0cQY4BL53GMcGOhRQHy9Bxb_Bt-x6H4hU8lS3UhVMswFS0iNFBmthns62v1pQYmNhErDI0ex9d4O9tdgDM6mAVLKshBnUjMrrxtRDCpo7R0v-ugronKS4UVqi2jUbZtjGbQCbQWR&src-supplier=Texas+Instruments; ComponentLink2URL: https://octopart.com:443/opatz8j6/c1?t=3Kn-x-B12yt8cyigldGRK0fr5vnz-lM8Mqch7NdQ2V-LWPUAJNs9So97ApvASyAHA8iK1-lIZdI4MBXwJrEazVMj19I_dzq2jgAHzcK1mcn17bmGuFTeYv25lnKGxLEpMeyj63YQKoaUa7DUxTnjTjc01TQWBA7m8KdFiuahxeRw4IunbreEqAhJd-oxEYd84chu3xmKAs9bqn9Bo89VVi9aAN8KSt32Fzec8xr4Pr_eThut1ziATMBMqOF9rb0h5nPP0cQY4BL53GMcGOhRQHy9Bxb_Bt-x6H4hU8lS3UhVMswFS0iNFBmthns62v1pQYmNhErDI0ex9d4O9tdgDM6mAVLKshBnUjMrrxtRDCpo7R0v-ugronKS4UVqi2jUbZtjGbQCbQWR&src-supplier=Texas+Instruments; ComponentLink3Description: https://sigma.octopart.com:443/179668864/technical_drawing/Texas-Instruments-TPSM365R15FRDNR.pdf?src-supplier=Texas+Instruments; ComponentLink3URL: https://sigma.octopart.com:443/179668864/technical_drawing/Texas-Instruments-TPSM365R15FRDNR.pdf?src-supplier=Texas+Instruments; ComponentLink4Description: https://datasheet.octopart.com:443/TPSM365R15FRDNR-Texas-Instruments-datasheet-188745015.pdf?src-supplier=LCSC; ComponentLink4URL: https://datasheet.octopart.com:443/TPSM365R15FRDNR-Texas-Instruments-datasheet-188745015.pdf?src-supplier=LCSC; ComponentLink5Description: http://sigma.octopart.com:80/reach_statement/Texas-Instruments-company-64.pdf?src-supplier=Compliance+Statements; ComponentLink5URL: http://sigma.octopart.com:80/reach_statement/Texas-Instruments-company-64.pdf?src-supplier=Compliance+Statements; ComponentLink6Description: http://sigma.octopart.com:80/conflict_mineral_statement/Texas-Instruments-company-63.pdf?src-supplier=Compliance+Statements; ComponentLink6URL: http://sigma.octopart.com:80/conflict_mineral_statement/Texas-Instruments-company-63.pdf?src-supplier=Compliance+Statements; Height: 0m; Length: 4.5mm; Lifecycle Status: Production; Manufacturer Part Number: TPSM365R15FRDNR; Pins: 11; Pricing: 1=3.77, 10=2.845, 25=2.6132, 100=2.3585, 250=2.23712, 500=2.16394, 1000=2.10373 (USD); RoHS: Compliant; Stock: 3432; Supplier: DigiKey; Supplier Part Number: 296-TPSM365R15FRDNRCT-ND; Thickness: 2mm; Width: 3.5mm; Library Name: heating_sch_lib1.SchLib
U2 = UCC27524A1QDGNRQ1: IRON_DRIVER: IC GATE DRVR LOW-SIDE 8MSOP Low-Side Gate Driver IC Non-Inverting 8-HVSSOP; Manufacturer: Texas Instruments; Manufacturer Lifecycle Status: ACTIVE; Number of Channels: 2; Category: Gate Drivers; Component Kind: Standard; ComponentLink1Description: https://sigma.octopart.com:443/182858100/technical_drawing/Texas-Instruments-UCC27524A1QDGNRQ1.pdf?src-supplier=Texas+Instruments; ComponentLink1URL: https://sigma.octopart.com:443/182858100/technical_drawing/Texas-Instruments-UCC27524A1QDGNRQ1.pdf?src-supplier=Texas+Instruments; ComponentLink2Description: https://octopart.com:443/opatz8j6/c1?t=mKOzLH28pN_CgkCAHLcdtjxUd4dLN-Tn4zY6mg4j0l4BX0KCGI7LPFHrtgT1qJreaPB-eiJodYU0OUpJvaqmvjzn6xaL437JOESplyJ6xf3ugOpsdFeQbxPX4uEWsku6qnM3sSE1T_N-bRrlR1-6H1VwMT_NEoPNO3XVSD4yXArGs-RBPbnWzGbqYMplwbsP748jWsSvVOZd2jWxTbfRL3AIr1SfB67BLEmiauUHuj0AtOdvsMyIkQMYc8kEI9zM-K6I2S8pTB5tGVQ8tzjIBVnjBimhZbL1HS8zTAdV_yVNMb_PZAmGR0ol8T0bf_LCpqxlyov6d_wfk27-iE5OcE75MhWKqSoYCM-i5sQNybFyN4CF7tLXuQzJpuXiW636waCXRYNNaOY2vI0&src-supplier=Texas+Instruments; ComponentLink2URL: https://octopart.com:443/opatz8j6/c1?t=mKOzLH28pN_CgkCAHLcdtjxUd4dLN-Tn4zY6mg4j0l4BX0KCGI7LPFHrtgT1qJreaPB-eiJodYU0OUpJvaqmvjzn6xaL437JOESplyJ6xf3ugOpsdFeQbxPX4uEWsku6qnM3sSE1T_N-bRrlR1-6H1VwMT_NEoPNO3XVSD4yXArGs-RBPbnWzGbqYMplwbsP748jWsSvVOZd2jWxTbfRL3AIr1SfB67BLEmiauUHuj0AtOdvsMyIkQMYc8kEI9zM-K6I2S8pTB5tGVQ8tzjIBVnjBimhZbL1HS8zTAdV_yVNMb_PZAmGR0ol8T0bf_LCpqxlyov6d_wfk27-iE5OcE75MhWKqSoYCM-i5sQNybFyN4CF7tLXuQzJpuXiW636waCXRYNNaOY2vI0&src-supplier=Texas+Instruments; ComponentLink3Description: https://sigma.octopart.com:443/49250750/technical_drawing/Texas-Instruments-UCC27524A1QDGNRQ1.pdf?src-supplier=Texas+Instruments; ComponentLink3URL: https://sigma.octopart.com:443/49250750/technical_drawing/Texas-Instruments-UCC27524A1QDGNRQ1.pdf?src-supplier=Texas+Instruments; ComponentLink4Description: https://datasheet.octopart.com:443/UCC27524A1QDGNRQ1-Texas-Instruments-datasheet-164421433.pdf?src-supplier=SHENGYU+ELECTRONICS; ComponentLink4URL: https://datasheet.octopart.com:443/UCC27524A1QDGNRQ1-Texas-Instruments-datasheet-164421433.pdf?src-supplier=SHENGYU+ELECTRONICS; ComponentLink5Description: http://sigma.octopart.com:80/reach_statement/Texas-Instruments-company-64.pdf?src-supplier=Compliance+Statements; ComponentLink5URL: http://sigma.octopart.com:80/reach_statement/Texas-Instruments-company-64.pdf?src-supplier=Compliance+Statements; ComponentLink6Description: http://sigma.octopart.com:80/conflict_mineral_statement/Texas-Instruments-company-63.pdf?src-supplier=Compliance+Statements; ComponentLink6URL: http://sigma.octopart.com:80/conflict_mineral_statement/Texas-Instruments-company-63.pdf?src-supplier=Compliance+Statements; Height: 1.1mm; Length: 3mm; Lifecycle Status: Production; Manufacturer Part Number: UCC27524A1QDGNRQ1; Max Operating Temperature: 125°C; Min Operating Temperature: -40°C; Pins: 8; Pricing: 1=1.73, 10=1.271, 25=1.156, 100=1.029, 250=0.96848, 500=0.93202, 1000=0.90201 (USD); RoHS: Compliant; Stock: 4328; Supplier: DigiKey; Supplier Part Number: 296-46913-1-ND; Thickness: 1.02mm; Width: 3mm; Library Name: heating_sch_lib1.SchLib
